# Altium schematic sheet TF_CARD&USB.SchDoc(TF_CARD&USB)
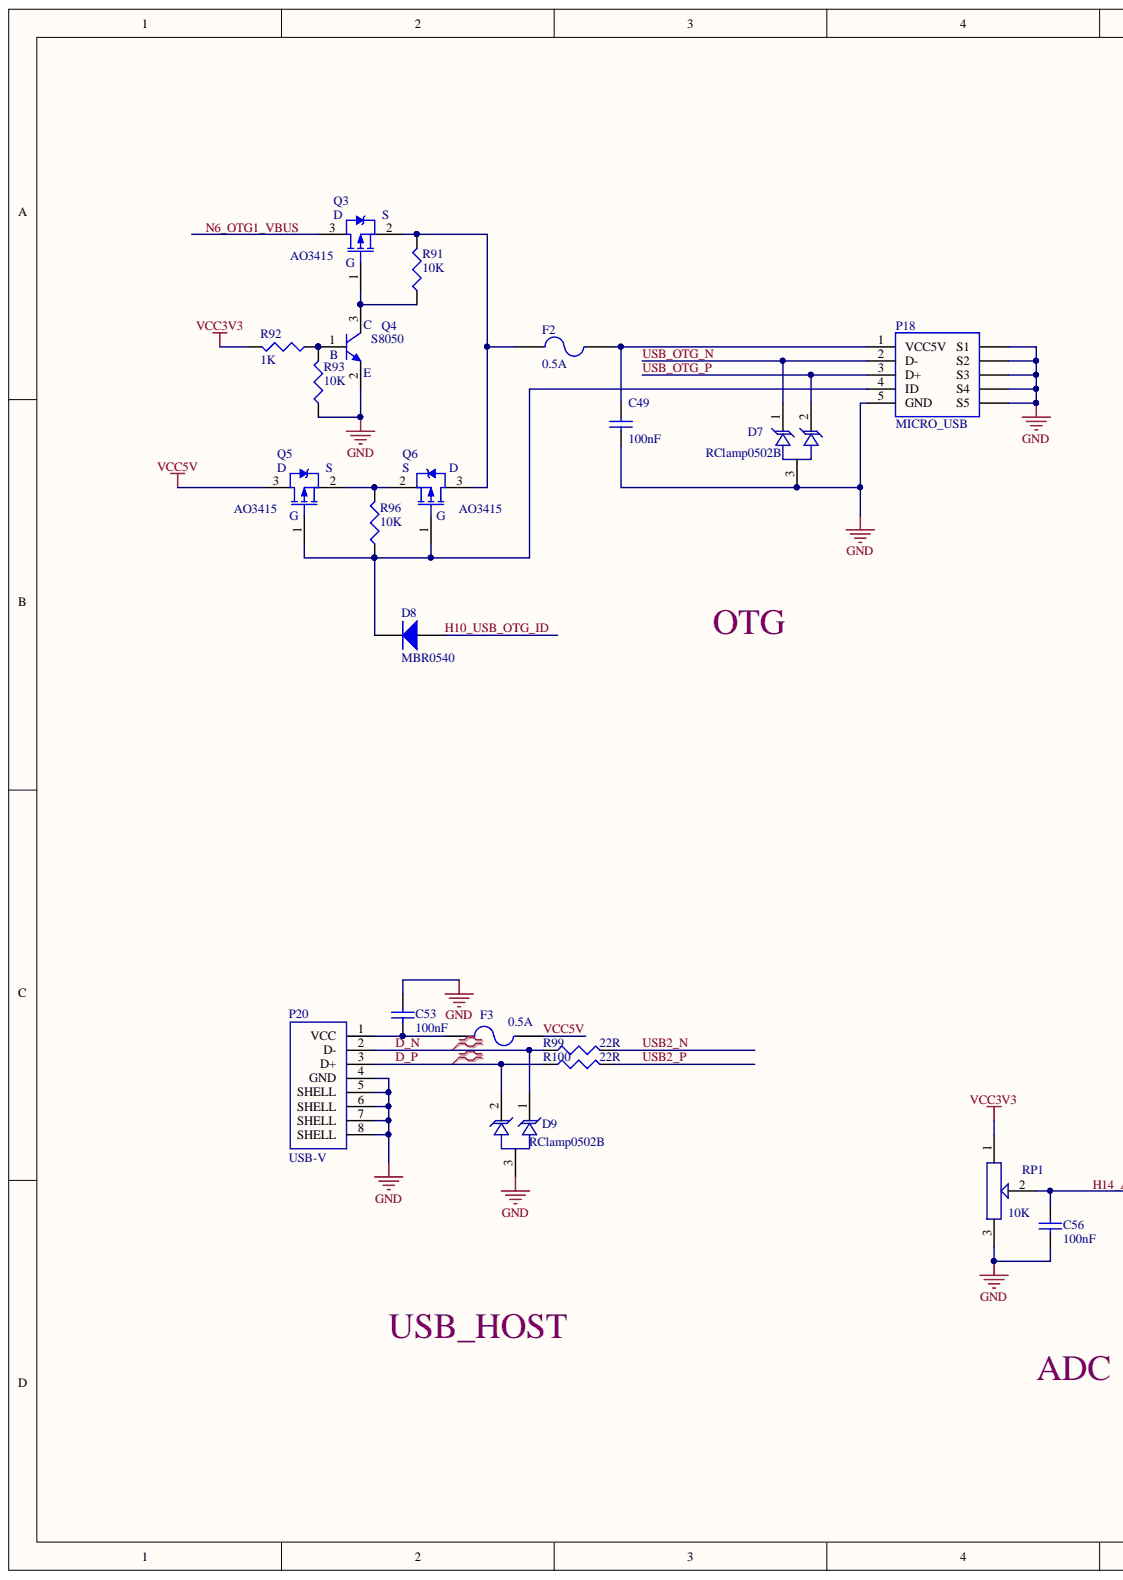
[diagram p0: TF_CARD&USB.SchDoc, left]
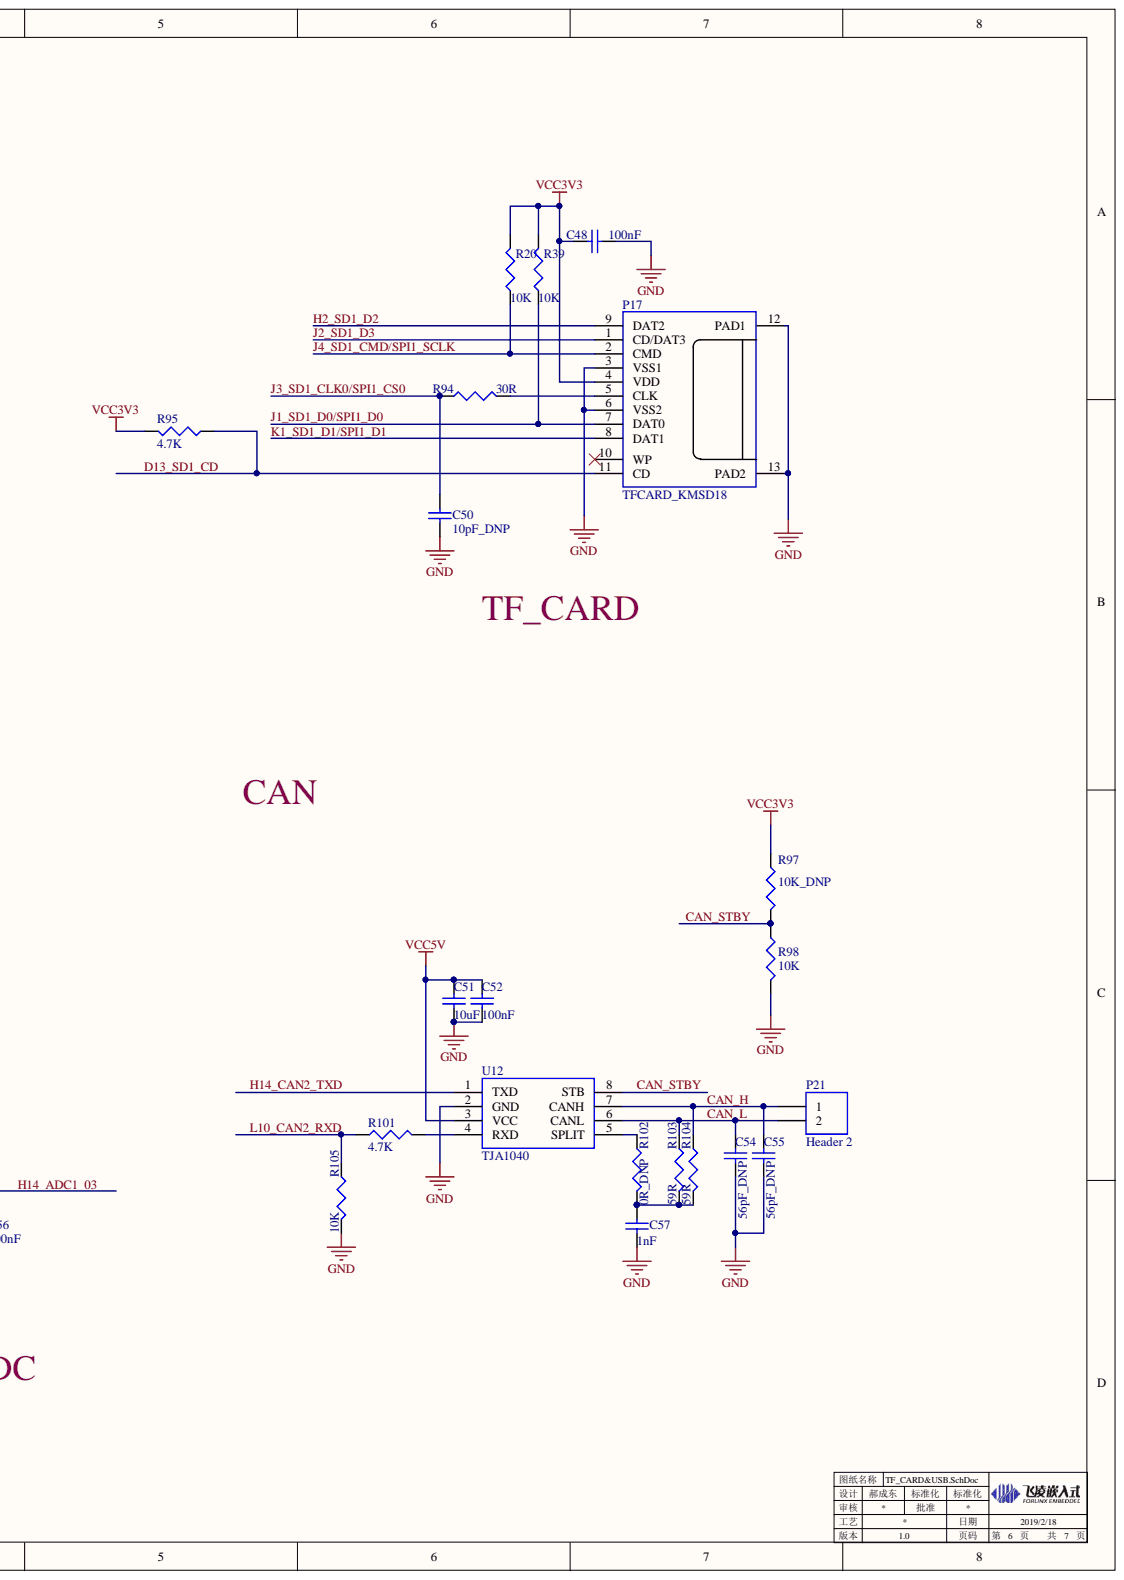
[diagram p1: TF_CARD&USB.SchDoc, right]

## Parts
C48  100nF  [0603C+]
C49  100nF  [0603C+]
C50  10pF_DNP  [0603C+]
C51  10uF  [0603C+]
C52  100nF  [0603C+]
C53  100nF  [0603C+]
C54  56pF_DNP  [0603C+]
C55  56pF_DNP  [0603C+]
C56  100nF  [0603C+]
C57  1nF  [0603C+]
D7  RClamp0502B  [SOT-523]
D8  MBR0540  [Diode1.6*2.6]
D9  RClamp0502B  [SOT-523]
F2  0.5A  [R_1210]
F3  0.5A  [R_1210]
P17  TFCARD_KMSD18  [TF-CARD]
P18  MICRO_USB  [microUSB]
P20  USB-V  [USB-C]
P21  Header 2  [DG128-2P]
Q3  AO3415  [SOT95P245X110-3N]
Q4  S8050  [SOT95P245X110-3N]
Q5  AO3415  [SOT95P245X110-3N]
Q6  AO3415  [SOT95P245X110-3N]
R20  10K  [0603R+]
R39  10K  [0603R+]
R91  10K  [0603R+]
R92  1K  [0603R+]
R93  10K  [0603R+]
R94  30R  [0603R+]
R95  4.7K  [0603R+]
R96  10K  [0603R+]
R97  10K_DNP  [0603R+]
R98  10K  [0603R+]
R99  22R  [0603R+]
R100  22R  [0603R+]
R101  4.7K  [0603R+]
R102  0R_DNP  [0603R+]
R103  59R  [0603R+]
R104  59R  [0603R+]
R105  10K  [0603R+]
RP1  value 10K  [3296W]  (hidden comment: VR-3296W)
U12  TJA1040  [SOP127P600X175-8N]

## Nets
GND: C48.1 C49.1 C50.2 C51.2 C52.2 C53.1 C54.2 C55.2 C56.1 C57.2 D7.3 D9.3 P17.3(VSS1) P17.6(VSS2) P17.12(PAD1) P17.13(PAD2) P18.5(GND) P18.6(S1) P18.7(S2) P18.8(S3) P18.9(S4) P18.10(S5) P20.4(GND) P20.5(SHELL) P20.6(SHELL) P20.7(SHELL) P20.8(SHELL) Q4.2 R93.1 R98.2 R105.2 RP1.3 U12.2(GND)
VCC3V3: C48.2 P17.4(VDD) R20.2 R39.2 R92.2 R95.2 R97.1 RP1.1
CAN_H: C55.1 P21.1 R104.1 U12.7(CANH)
CAN_L: C54.1 P21.2 R103.1 U12.6(CANL)
CAN_STBY: R97.2 R98.1 U12.8(STB)
D13_SD1_CD: P17.11(CD) R95.1
D_N: D9.1 P20.2(D-) R99.1
D_P: D9.2 P20.3(D+) R100.1
H2_SD1_D2: P17.9(DAT2)
H10_USB_OTG_ID: D8.1
H14_ADC1_03: C56.2 RP1.2
H14_CAN2_TXD: U12.1(TXD)
J1_SD1_D0/SPI1_D0: P17.7(DAT0) R39.1
J2_SD1_D3: P17.1(CD/DAT3)
J3_SD1_CLK0/SPI1_CS0: C50.1 R94.1
J4_SD1_CMD/SPI1_SCLK: P17.2(CMD) R20.1
K1_SD1_D1/SPI1_D1: P17.8(DAT1)
L10_CAN2_RXD: R101.1 R105.1
N6_OTG1_VBUS: Q3.3
USB2_N: R99.2
USB2_P: R100.2
USB_OTG_N: D7.1 P18.2(D-)
USB_OTG_P: D7.2 P18.3(D+)
VCC5V: C51.1 C52.1 F3.1 Q5.3 U12.3(VCC)
NetC49_2: C49.2 F2.1 P18.1(VCC5V)
NetC53_2: C53.2 F3.2 P20.1(VCC)
NetC57_1: C57.1 R102.2 R103.2 R104.2
NetD8_2: D8.2 P18.4(ID) Q5.1 Q6.1 R96.1
NetF2_2: F2.2 Q3.2 Q6.3 R91.2
NetP17_5: P17.5(CLK) R94.2
NetQ3_1: Q3.1 Q4.3 R91.1
NetQ4_1: Q4.1 R92.1 R93.2
NetQ5_2: Q5.2 Q6.2 R96.2
NetR101_2: R101.2 U12.4(RXD)
NetR102_1: R102.1 U12.5(SPLIT)

Not connected: P17 10(WP)

## Library data of the parts
C48-C57 = Cap: Capacitor; Simulation: CAP; Signal Integrity: Cap; Published: 8-Jun-2000; PackageReference: RAD-0.3; PackageDescription: Radial Cap, Thru-Hole; 2 Leads; 0.3 in Pin Spacing; Library Name: Miscellaneous Devices.IntLib; LatestRevisionNote: Re-released for DXP Platform.; LatestRevisionDate: 17-Jul-2002; Component Kind: Standard; Publisher: Altium Limited
  C48 C49 C52 C53 C56: Value: 100nF
  C50: Value: 10pF_DNP
  C51: Value: 10uF
  C54 C55: Value: 56pF_DNP
  C57: Value: 1nF
D7 D9 = LCTVS: Value: RClamp0502B; Library Name: Sch.SchLib; Component Kind: Standard
D8 = Diode: Default Diode; Simulation: DIODE; Signal Integrity: Diode; Published: 8-Jun-2000; PackageVersion: Sep-1996; PackageReference: SMC; PackageDescription: DO-214-AB/SMC; 2 C-Bend Leads; Body 7.9 x 5.9 mm, inc. leads (LxW); Library Name: Miscellaneous Devices.IntLib; LatestRevisionNote: Re-released for DXP Platform.; LatestRevisionDate: 17-Jul-2002; Component Kind: Standard; Code_JEDEC: DO-214-AB; Publisher: Altium Limited
F2 F3 = Fuse 2: Fuse; Value: 0.5A; Simulation: FUSE; Published: 8-Jun-2000; PackageReference: PIN-W2/E2.8; PackageDescription: Thru-Hole; 2 Leads; Library Name: Miscellaneous Devices.IntLib; LatestRevisionNote: Re-released for DXP Platform.; LatestRevisionDate: 17-Jul-2002; Component Kind: Standard; Publisher: Altium Limited
P17 = SDCARD_KMSD18: Library Name: IMX6QP.SchLib; Component Kind: Standard
P18 = MICRO_USB: Header, 5-Pin, Dual row; VALUE: MICRO_USB; Signal Integrity: Connector; Library Name: PCB_RT1052.SCHLIB; LatestRevisionNote: Re-released for DXP Platform.; LatestRevisionDate: 17-Jul-2002; Component Kind: Standard; Publisher: Altium Limited
Q3 Q5 Q6 = PMOS: N-Channel Power MOSFET; Note: Pin 2 is electrically connected to pin 4.; VALUE: AO3415; Simulation: IRF1010; Published: 8-Jun-2000; PackageVersion: Feb-1997; PackageReference: TO-220AB; PackageDescription: TO, Thru-Hole, Vertical, Heatsink Mounted; 3 In-Line Leads; Pitch 2.54 mm; Library Name: KQX6A.SchLib; LatestRevisionNote: Re-released for DXP Platform.; LatestRevisionDate: 17-Jul-2002; DatasheetVersion: PD-9.814; ComponentLink1URL: http://www.irf.com/; ComponentLink1Description: Manufacturer Link; Component Kind: Standard; Publisher: Altium Limited
Q4 = NPN: NPN Bipolar Transistor; VALUE: S8050; Simulation: NPN; Signal Integrity: NPN; Published: 8-Jun-2000; PackageReference: TO-226-AA; PackageDescription: TO, Flat Index; 3 In-Line, Axial Leads; Body Dia. 4.8mm; Leads 0.55 x 0.51 mm (max); Library Name: KQX6A.SchLib; LatestRevisionNote: Re-released for DXP Platform.; LatestRevisionDate: 17-Jul-2002; Component Kind: Standard; Code_JEDEC: TO-226-AA; Publisher: Altium Limited
R20 R39 R91-R105 = Res3: Resistor; Simulation: RESISTOR; Signal Integrity: Res; Published: 8-Jun-2000; PackageReference: J1-0603; PackageDescription: Chip Resistor; Library Name: Miscellaneous Devices.IntLib; LatestRevisionNote: IPC-7351 Footprint Added.; LatestRevisionDate: 29-May-2009; Component Kind: Standard; Publisher: Altium Limited
  R20 R39 R91 R93 R96 R98 R105: Value: 10K
  R92: Value: 1K
  R94: Value: 30R
  R95 R101: Value: 4.7K
  R97: Value: 10K_DNP
  R99 R100: Value: 22R
  R102: Value: 0R_DNP
  R103 R104: Value: 59R
RP1 = VR: Library Name: Sch.SchLib; Component Kind: Standard
P20 = USB-V: Library Name: IMX6QP-C.IntLib; Component Kind: Standard
P21 = Header 2: Library Name: PCB_RT1052.SCHLIB; Component Kind: Standard
U12 = TJA1040: Library Name: IMX6QP.SchLib; Component Kind: Standard
